# Altium schematic sheet My_E-Paper_Driver_V2.1.0.SchDoc
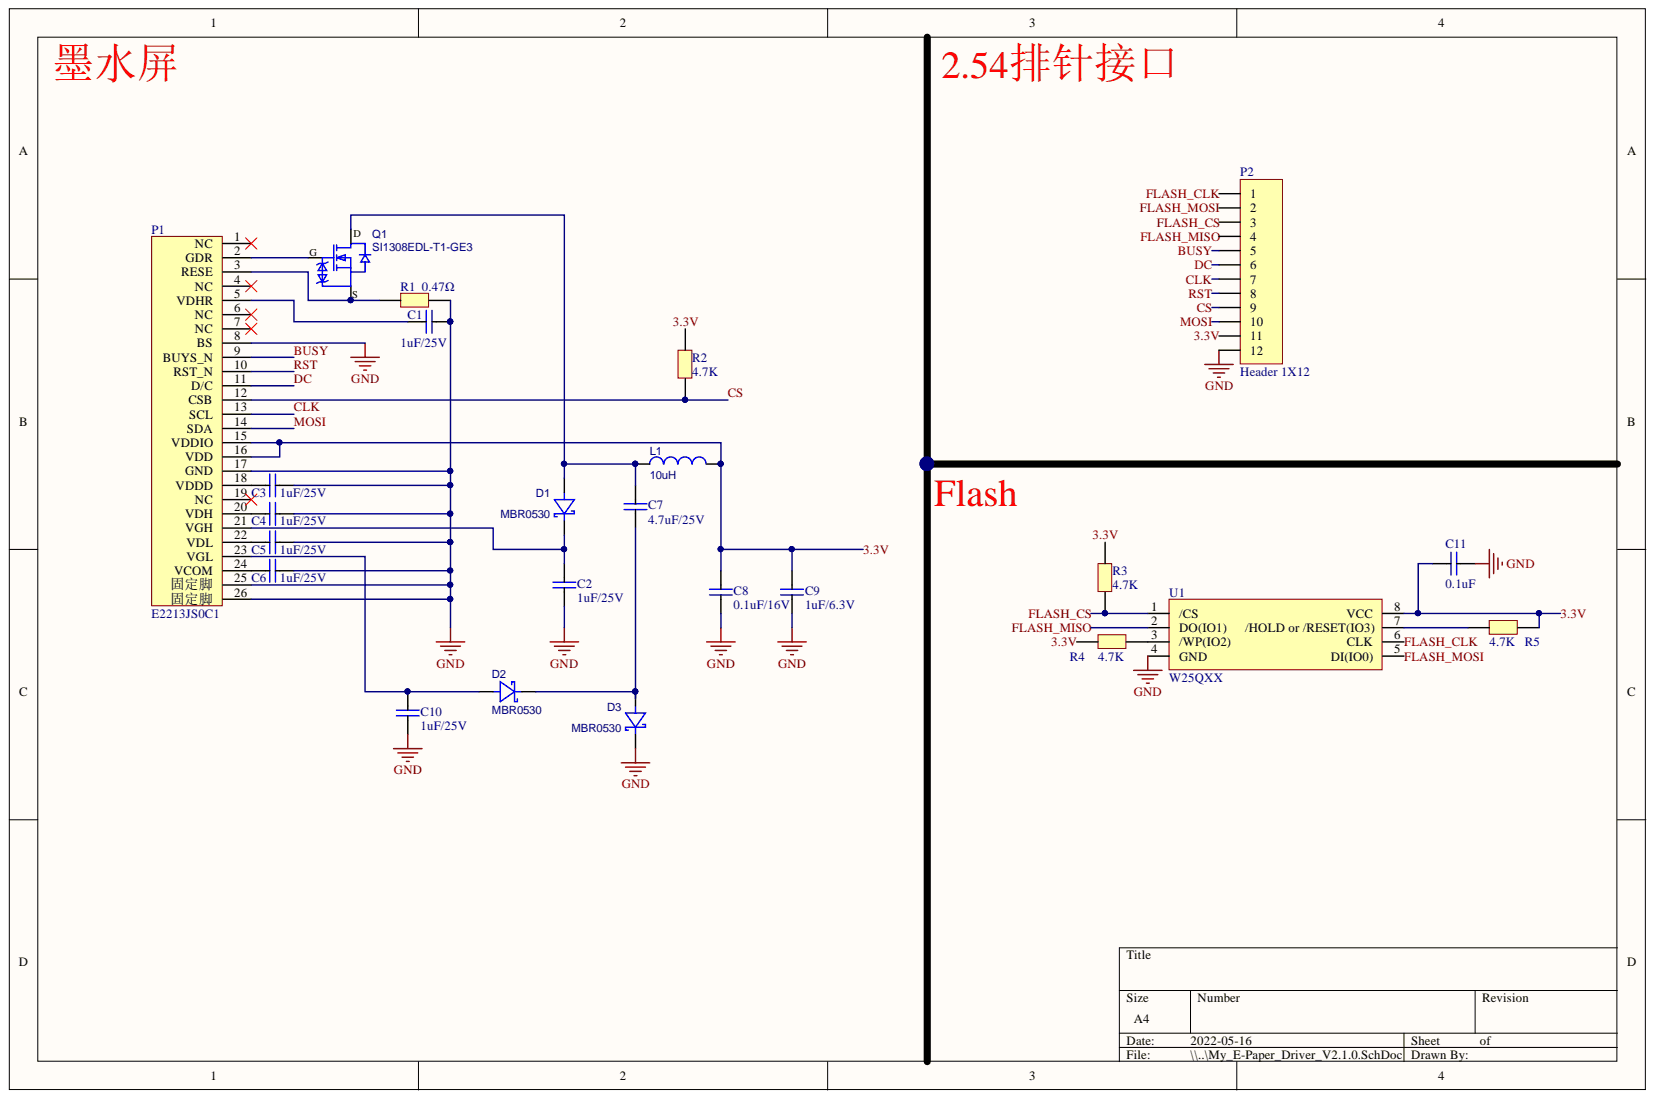
[diagram p0: My_E-Paper_Driver_V2.1.0.SchDoc]

## Parts
C1  1uF/25V  [C0603]
C2  1uF/25V  [C0603]
C3  1uF/25V  [C0603]
C4  1uF/25V  [C0603]
C5  1uF/25V  [C0603]
C6  1uF/25V  [C0603]
C7  4.7uF/25V  [C0603]
C8  0.1uF/16V  [C0603]
C9  1uF/6.3V  [C0603]
C10  1uF/25V  [C0603]
C11  0.1uF  [C0603]
D1  MBR0530  [SOD-123_L2.7-W1.6-LS3.7-RD-1]
D2  MBR0530  [SOD-123_L2.7-W1.6-LS3.7-RD-1]
D3  MBR0530  [SOD-123_L2.7-W1.6-LS3.7-RD-1]
L1  10uH  [IND-SMD_L4.5-W4.0_CD43]
P1  E2213JS0C1  [E2213JS0C1]
P2  Header 1X12  [1X12_2.54]
Q1  SI1308EDL-T1-GE3  [SOT-323_L2.0-W1.3-P1.30-LS2.1-BR]
R1  0.47Ω  [RES-0805]
R2  4.7K  [RES-0603]
R3  4.7K  [RES-0603]
R4  4.7K  [RES-0603]
R5  4.7K  [RES-0603]
U1  W25QXX  [SOIC-8_150MIL]

## Nets
GND: C1.2 C2.1 C3.2 C4.2 C5.2 C6.2 C8.1 C9.1 C10.1 C11.1 D3.1 P1.8(BS) P1.17(GND) P1.25(固定脚) P1.26(固定脚) P2.12 R1.2 U1.4(GND)
3.3V: C8.2 C9.2 C11.2 L1.2 P1.15(VDDIO) P1.16(VDD) P2.11 R2.1 R3.1 R4.1 R5.2 U1.8(VCC)
BUSY: P1.9(BUYS_N) P2.5
CLK: P1.13(SCL) P2.7
CS: P1.12(CSB) P2.9 R2.2
DC: P1.11(D/C) P2.6
FLASH_CLK: P2.1 U1.6(CLK)
FLASH_CS: P2.3 R3.2 U1.1(/CS)
FLASH_MISO: P2.4 U1.2(DO(IO1))
FLASH_MOSI: P2.2 U1.5(DI(IO0))
MOSI: P1.14(SDA) P2.10
RST: P1.10(RST_N) P2.8
NetC1_1: C1.1 P1.5(VDHR)
NetC2_2: C2.2 D1.1 P1.21(VGH)
NetC3_1: C3.1 P1.18(VDDD)
NetC4_1: C4.1 P1.20(VDH)
NetC5_1: C5.1 P1.22(VDL)
NetC6_1: C6.1 P1.24(VCOM)
NetC7_1: C7.1 D2.1 D3.2
NetC7_2: C7.2 D1.2 L1.1 Q1.3
NetC10_2: C10.2 D2.2 P1.23(VGL)
NetP1_2: P1.2(GDR) Q1.1
NetP1_3: P1.3(RESE) Q1.2 R1.1
NetR4_2: R4.2 U1.3(/WP(IO2))
NetR5_1: R5.1 U1.7
N00012:

Not connected: P1 1(NC) 4(NC) 6(NC) 7(NC) 19(NC)

## Library data of the parts
C1-C11 = Cap: Component Kind: Standard; Library Name: 电容.SchLib
D1-D3 = MBR0530: BOM_Manufacturer: Yangzhou Yangjie Elec Tech; Contributor: LCSC; BOM_JLCPCB Part Class: Extended Part; BOM_Manufacturer Part: MBR0530; BOM_Supplier: LCSC; BOM_Supplier Part: C699108; Component Kind: Standard; Library Name: 二极管.SchLib; spicePre: D; spiceSymbolName: MBR0530_C699108
L1 = 电感: BOM_Manufacturer: EATON; BOM_Manufacturer Part: DRA127-680-R; Contributor: LCSC; nameAlias: Inductance; BOM_Supplier: LCSC; BOM_Supplier Part: C445490; Component Kind: Standard; Library Name: 电感.SchLib; spicePre: L; spiceSymbolName: DRA127-680-R
P1 = E2213JS0C1: 立创海海外代购。2.13寸红黑白三色墨水屏。SPI接口。212x104; Component Kind: Standard; Library Name: 屏幕.SchLib
P2 = Header 1X12: Header, 1X12-Pin; Component Kind: Standard; Library Name: 接插件.SchLib
Q1 = SI1308EDL-T1-GE3: BOM_Manufacturer: VISHAY(威世); Contributor: LCSC; BOM_JLCPCB Part Class: Extended Part; BOM_Manufacturer Part: SI1308EDL-T1-GE3; BOM_Supplier: LCSC; BOM_Supplier Part: C469327; Component Kind: Standard; Library Name: MOS管.SchLib; spicePre: Q; spiceSymbolName: SI1308EDL-T1-GE3
R1-R5 = RES: Component Kind: Standard; Library Name: 电阻.SchLib
U1 = W25QXX: Component Kind: Standard; Library Name: 芯片.SchLib
